# Altium schematic sheet [20]-CONNECT_CONNECTORS.SchDoc([20]-CONNECT_CONNECTORS)
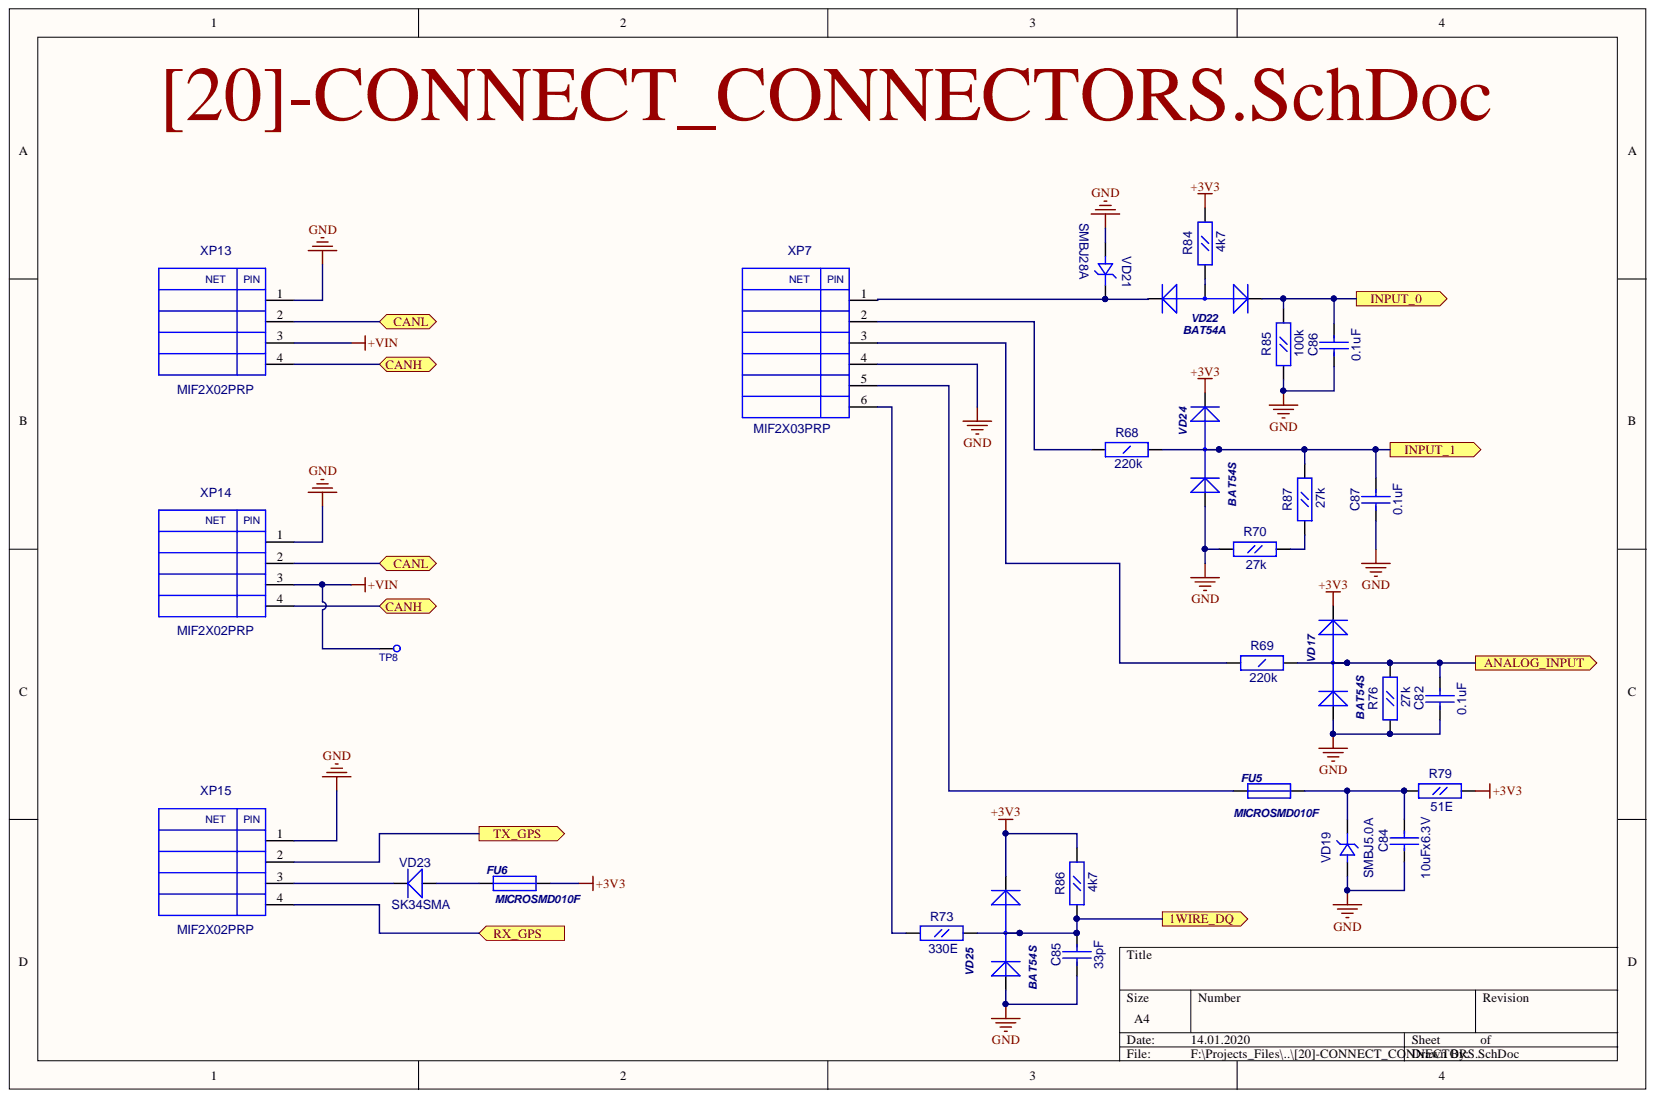
[diagram p0: [20]-CONNECT_CONNECTORS.SchDoc]

## Parts
C82  0.1uF  [CC0603]
C84  10uFx6.3V  [CC0805]
C85  33pF  [CC0603]
C86  0.1uF  [CC0603]
C87  0.1uF  [CC0603]
FU5  MICROSMD010F  [RC1210]
FU6  MICROSMD010F  [RC1210]
R68  220k  [RC1206]
R69  220k  [RC1206]
R70  27k  [RC0603]
R73  330E  [RC0603]
R76  27k  [RC0603]
R79  51E  [RC0603]
R84  4k7  [RC0603]
R85  100k  [RC0603]
R86  4k7  [RC0603]
R87  27k  [RC0603]
TP8  TESTPOINT1  [TESTPOINT1]  (value not drawn)
VD17  BAT54S  [SOT-23(GSD)]
VD19  SMBJ5.0A  [SMB]
VD21  SMBJ28A  [SMB]
VD22  BAT54A  [SOT-23(123)]
VD23  SK34SMA  [SMA]
VD24  BAT54S  [SOT-23(GSD)]
VD25  BAT54S  [SOT-23(GSD)]
XP7  MIF2X03PRP  [MIF2X03PRP]
XP13  MIF2X02PRP  [MIF2X02PRP]
XP14  MIF2X02PRP  [MIF2X02PRP]
XP15  MIF2X02PRP  [MIF2X02PRP]

## Ports
1WIRE_DQ, ANALOG_INPUT, CANH, CANL, INPUT_0, INPUT_1, RX_GPS, TX_GPS

## Nets
+3V3: FU6.2 R79.2 R84.2 R86.2 VD17.2 VD24.2 VD25.2
+VIN: TP8.1 XP13.3 XP14.3
GND: C82.1 C84.1 C85.1 C86.1 C87.1 R70.1 R76.1 R85.1 VD17.1 VD19.1 VD21.1 VD24.1 VD25.1 XP7.4 XP13.1 XP14.1 XP15.1
1WIRE_DQ: C85.2 R73.2 R86.1 VD25.3 ; port 1WIRE_DQ
ANALOG_INPUT: C82.2 R69.2 R76.2 VD17.3 ; port ANALOG_INPUT
CANH: XP13.4 XP14.4 ; port CANH
CANL: XP13.2 XP14.2 ; port CANL
INPUT_0: C86.2 R85.2 VD22.2 ; port INPUT_0
INPUT_1: C87.2 R68.2 R87.2 VD24.3 ; port INPUT_1
RX_GPS: XP15.4 ; port RX_GPS
TX_GPS: XP15.2 ; port TX_GPS
NetC84_2: C84.2 FU5.2 R79.1 VD19.2
NetFU5_1: FU5.1 XP7.5
NetFU6_1: FU6.1 VD23.1
NetR68_1: R68.1 XP7.2
NetR69_1: R69.1 XP7.3
NetR70_2: R70.2 R87.1
NetR73_1: R73.1 XP7.6
NetR84_1: R84.1 VD22.3
NetVD21_2: VD21.2 VD22.1 XP7.1
NetVD23_2: VD23.2 XP15.3

## Library data of the parts
C82 C85-C87 = CC0603: Description: CC0603; Component Kind: Standard
  C82 C86 C87: Value: 0.1uF
  C85: Value: 33pF; Library Name: fizik_lib (1).SchLib
  C82 C87: Library Name: gprs_modem_2.SchLib
  C86: Library Name: fizik_lib.SchLib
C84 = CC0805: Value: 10uFx6.3V; Description: CC0805; Component Kind: Standard; Library Name: fizik_lib.SchLib
FU5 FU6 = MICROSMD: Value: MICROSMD010F; Description: MICROSMD; Component Kind: Standard
  FU5: Library Name: fizik_lib (1).SchLib
  FU6: Library Name: fizik_lib.SchLib
R68 R69 = RC1206: Value: 220k; Description: RC1206; Component Kind: Standard; Library Name: fizik_lib.SchLib
R70 R73 R76 R79 R84-R87 = RC0603: Description: RC0603; Component Kind: Standard
  R70 R76 R87: Value: 27k
  R73: Value: 330E
  R79: Value: 51E
  R84 R86: Value: 4k7
  R85: Value: 100k
  R70 R84-R87: Library Name: fizik_lib.SchLib
  R73 R79: Library Name: fizik_lib (1).SchLib
  R76: Library Name: gprs_modem_2.SchLib
VD17 VD24 VD25 = BAT54S: 1.0 Ampere General Purpose Rectifier; ComponentField6: Published 8-Jun-2000, Modified 11-July-2000: SIM Model Added.; Simulation: DIODE; Component Kind: Standard
  VD17: Library Name: gprs_modem_2.SchLib
  VD24: Library Name: fizik_lib.SchLib
  VD25: Library Name: fizik_lib (1).SchLib
VD19 VD21 = SMBJ: Description: SMBJ; Component Kind: Standard
  VD19: Value: SMBJ5.0A; Library Name: fizik_lib (1).SchLib
  VD21: Value: SMBJ28A; Library Name: fizik_lib.SchLib
VD22 = BAT54A: 1.0 Ampere General Purpose Rectifier; ComponentField6: Published 8-Jun-2000, Modified 11-July-2000: SIM Model Added.; Simulation: DIODE; Component Kind: Standard; Library Name: fizik_lib.SchLib
VD23 = SK34SMA: Schottky Rectifier; Value: {Value}; Component Kind: Standard; Library Name: fizik_lib.SchLib
TP8 = TESTPOINT1: Description: TESTPOINT1; Component Kind: Standard; Library Name: fizik_lib (1).SchLib
XP7 = MIF2X03PRP: Description: MIF2X03PRP; Component Kind: Standard; Library Name: fizik_lib (1).SchLib
XP13-XP15 = MIF2X02PRP: Description: MIF2X02PRP; Component Kind: Standard; Library Name: fizik_lib.SchLib
